# Altium schematic sheet Display.SchDoc
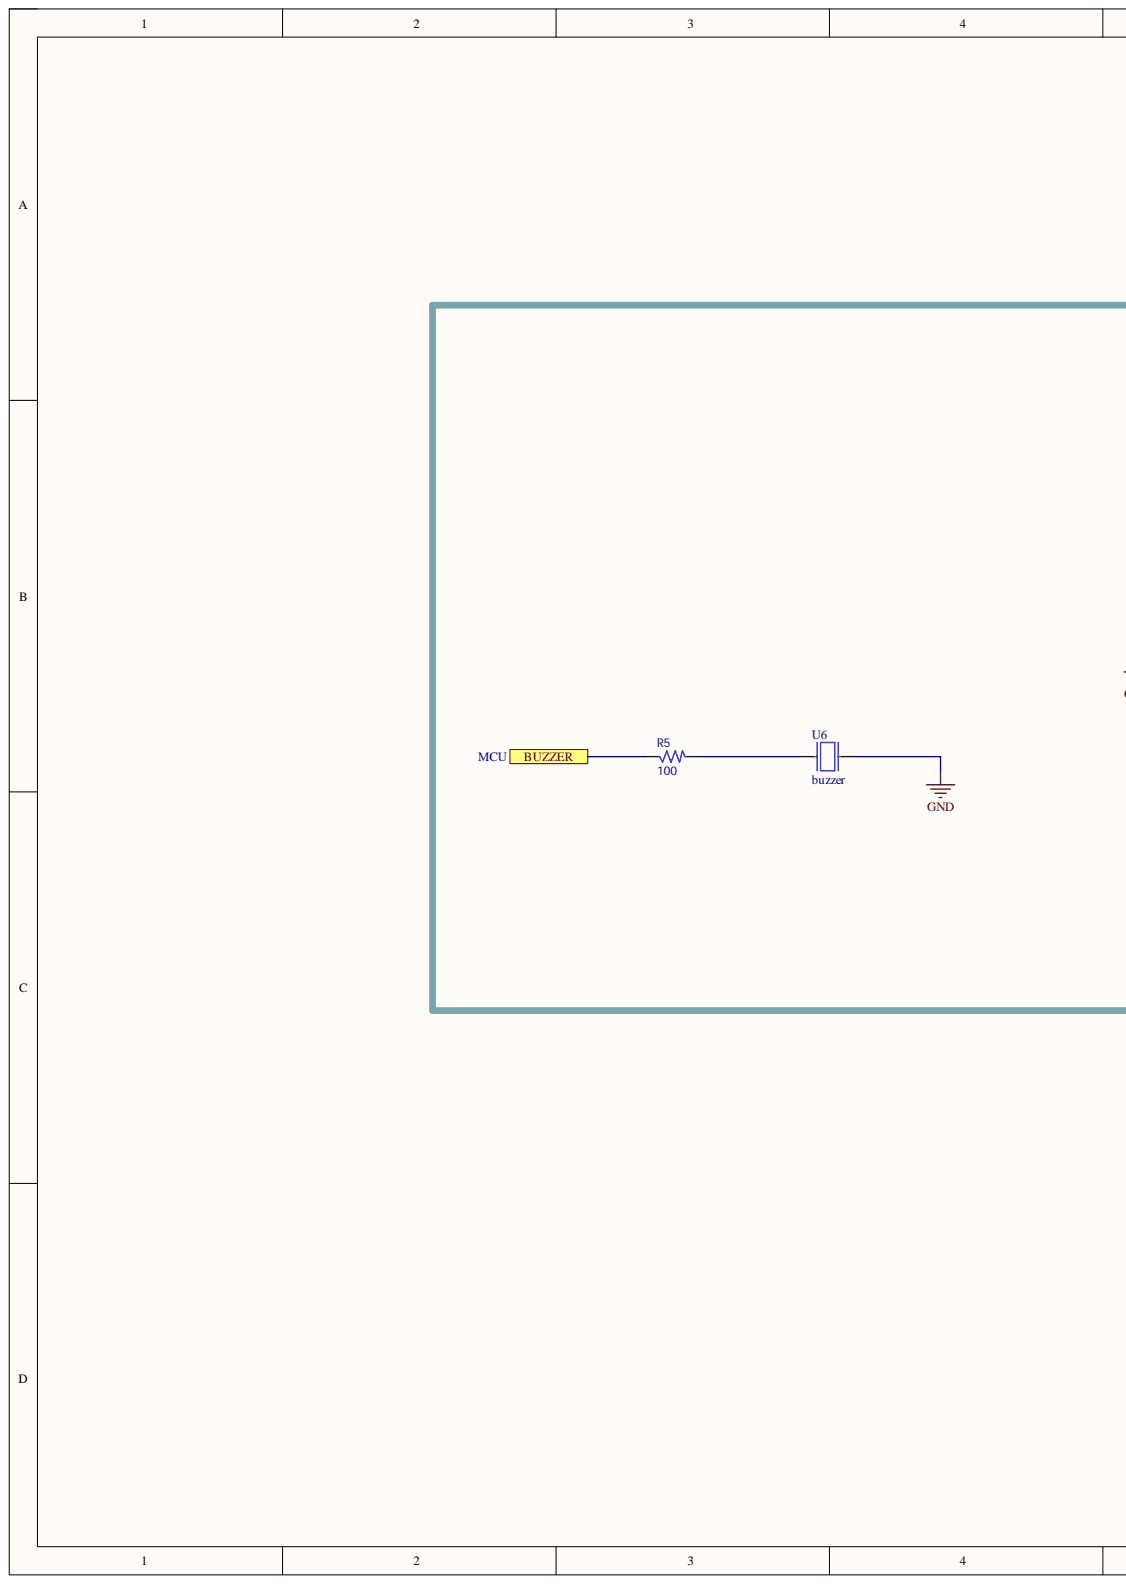
[diagram p0: Display.SchDoc, left]
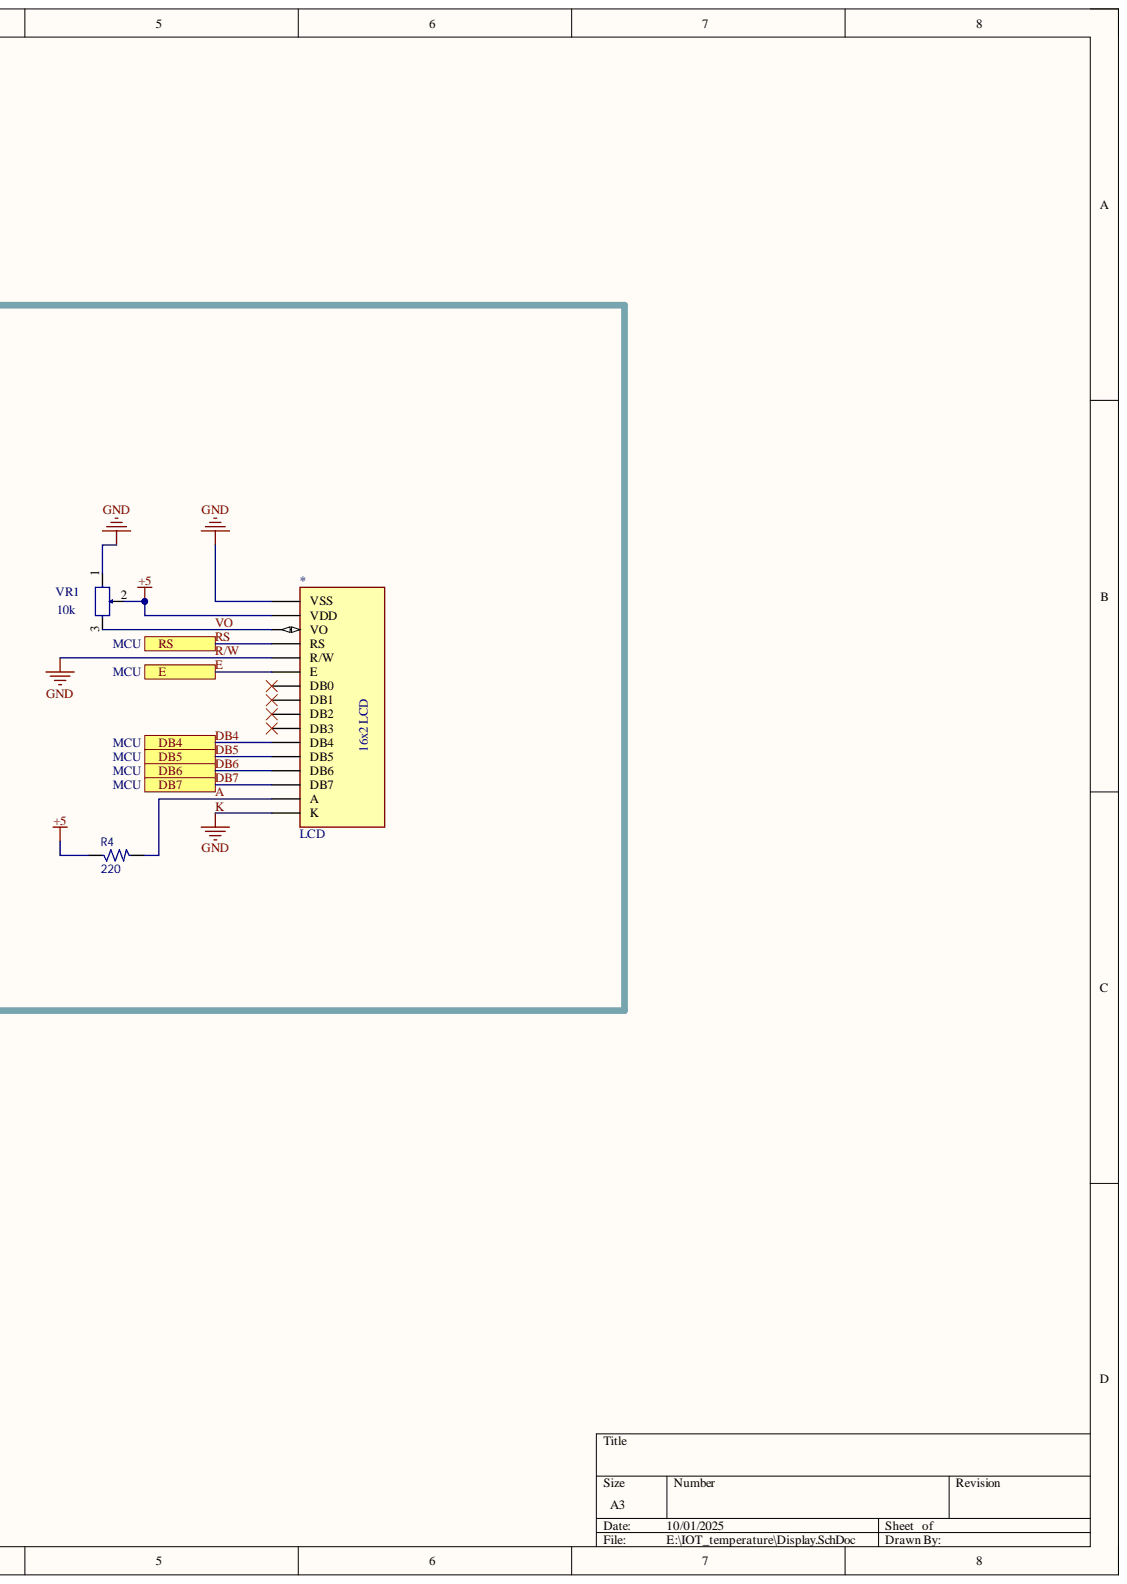
[diagram p1: Display.SchDoc, right]

## Parts
*  LCD  [LCD]
R4  220  [RESISTOR_0603]
R5  100  [RESISTOR_0603]
U6  buzzer  [buzzer]
VR1  10k  [VR-S1]

## Ports
BUZZER, DB4, DB5, DB6, DB7, E, RS

## Nets
+5: *.2(VDD) R4.1 VR1.2
A: *.15(A) R4.2
BUZZER: R5.1 ; port BUZZER
DB4: *.11(DB4) ; port DB4
DB5: *.12(DB5) ; port DB5
DB6: *.13(DB6) ; port DB6
DB7: *.14(DB7) ; port DB7
E: *.6(E) ; port E
K: *.1(VSS) *.5(R/W) *.16(K) U6.2 VR1.1
RS: *.4(RS) ; port RS
VO: *.3(VO) VR1.3
NetR5_2: R5.2 U6.1

Not connected: * 7(DB0) 8(DB1) 9(DB2) 10(DB3)

## Library data of the parts
R4 R5 = RES-SMD: Component Kind: Standard; Library Name: ID.SchLib; Signal Integrity: Guessed Model
VR1 = Resistor Var: Component Kind: Standard; Library Name: KhanhBK_Library_4.IntLib
* = LCD: Component Kind: Standard; Library Name: Schlib1.SchLib
U6 = buzzer: Component Kind: Standard; Library Name: Schlib1.SchLib
